# Altium schematic sheet CPU.SCHDOC(CPU)
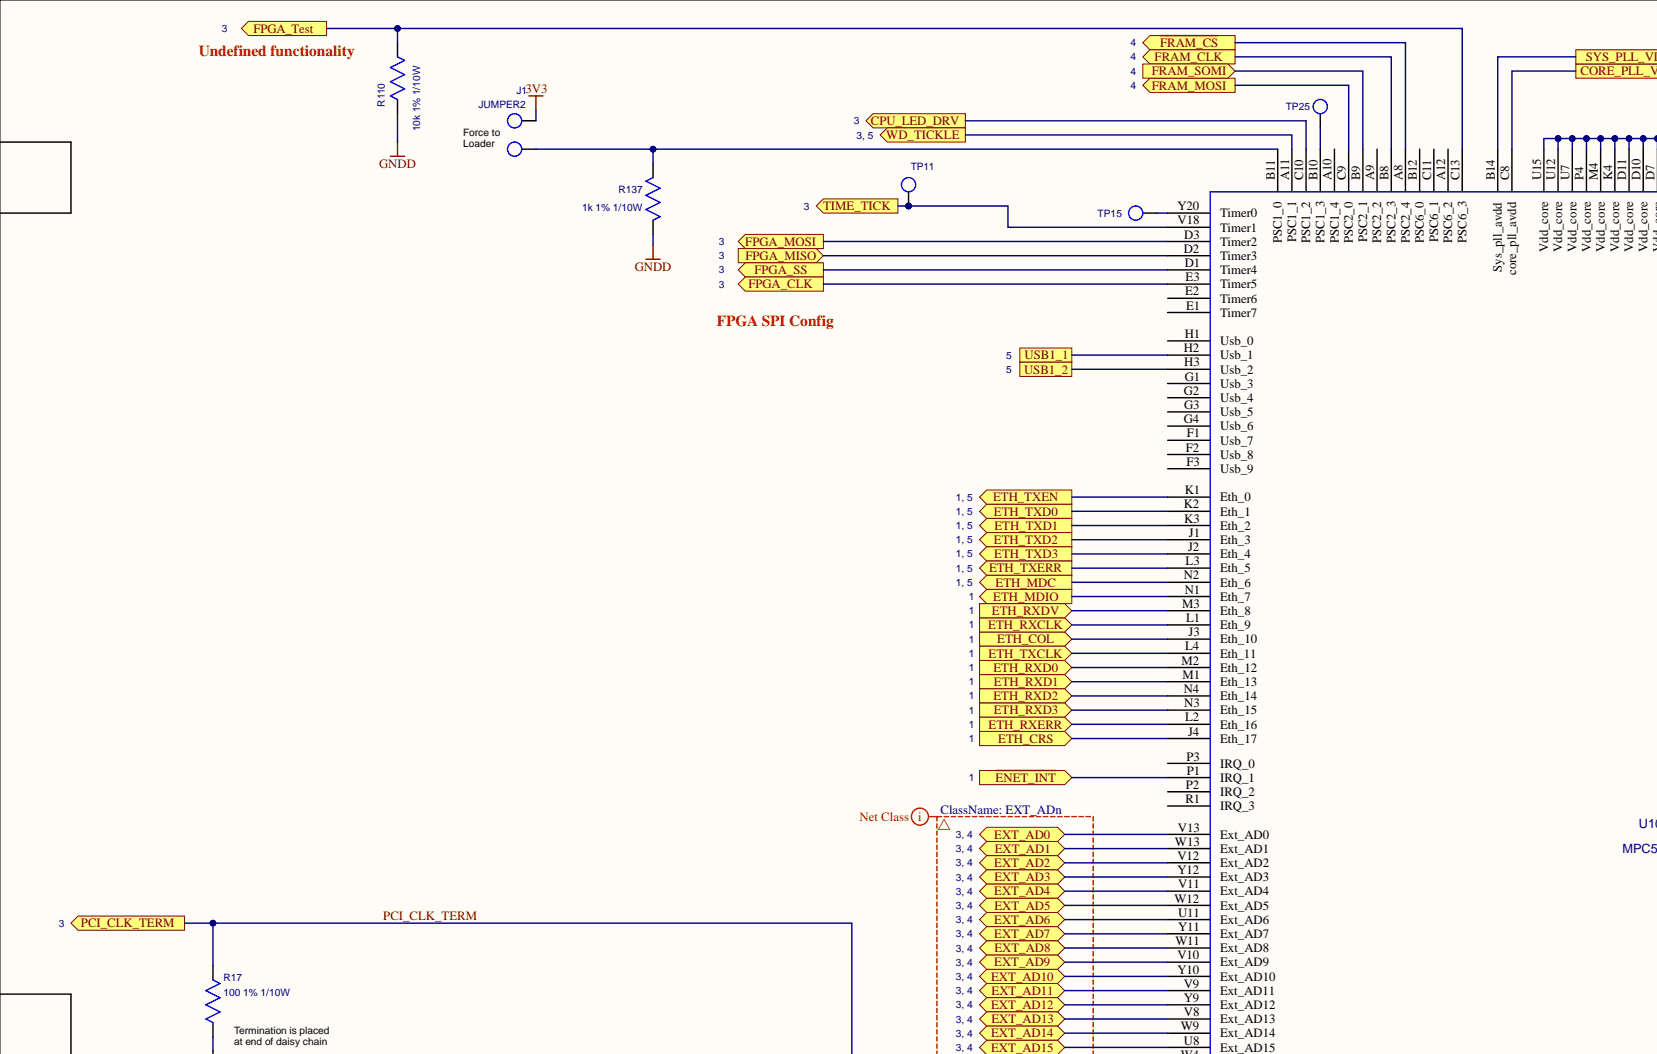
[diagram p0: CPU.SCHDOC, top left]
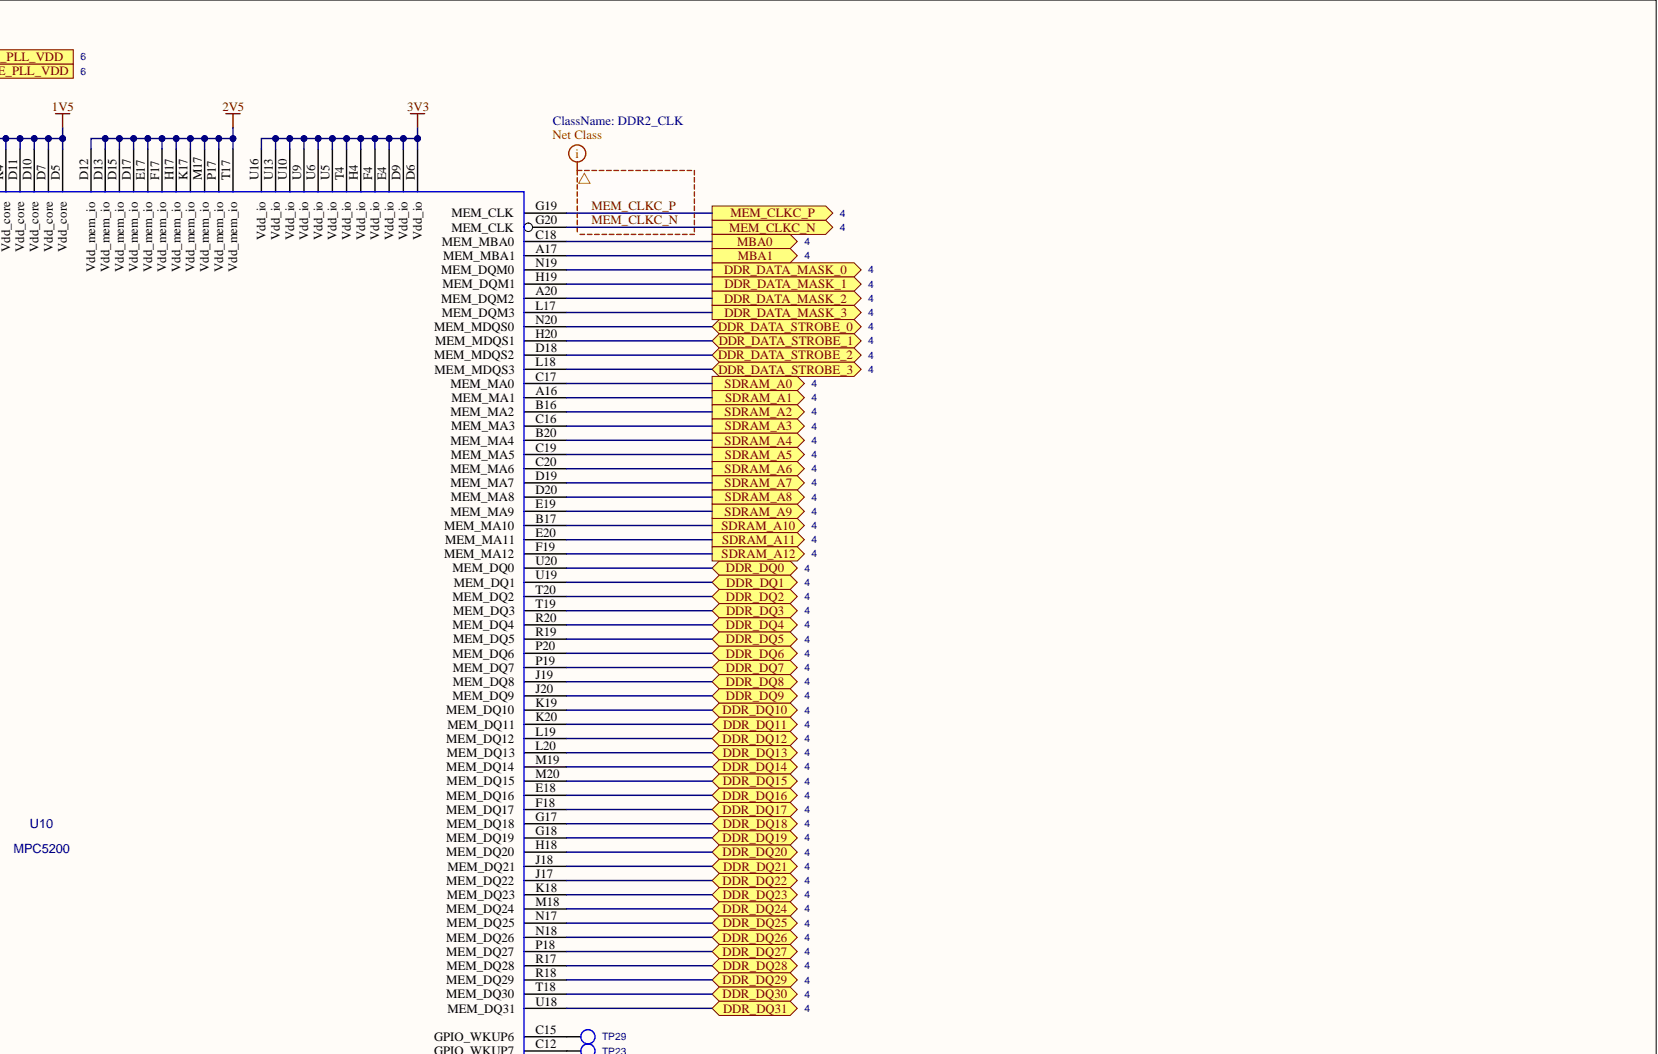
[diagram p1: CPU.SCHDOC, top right]
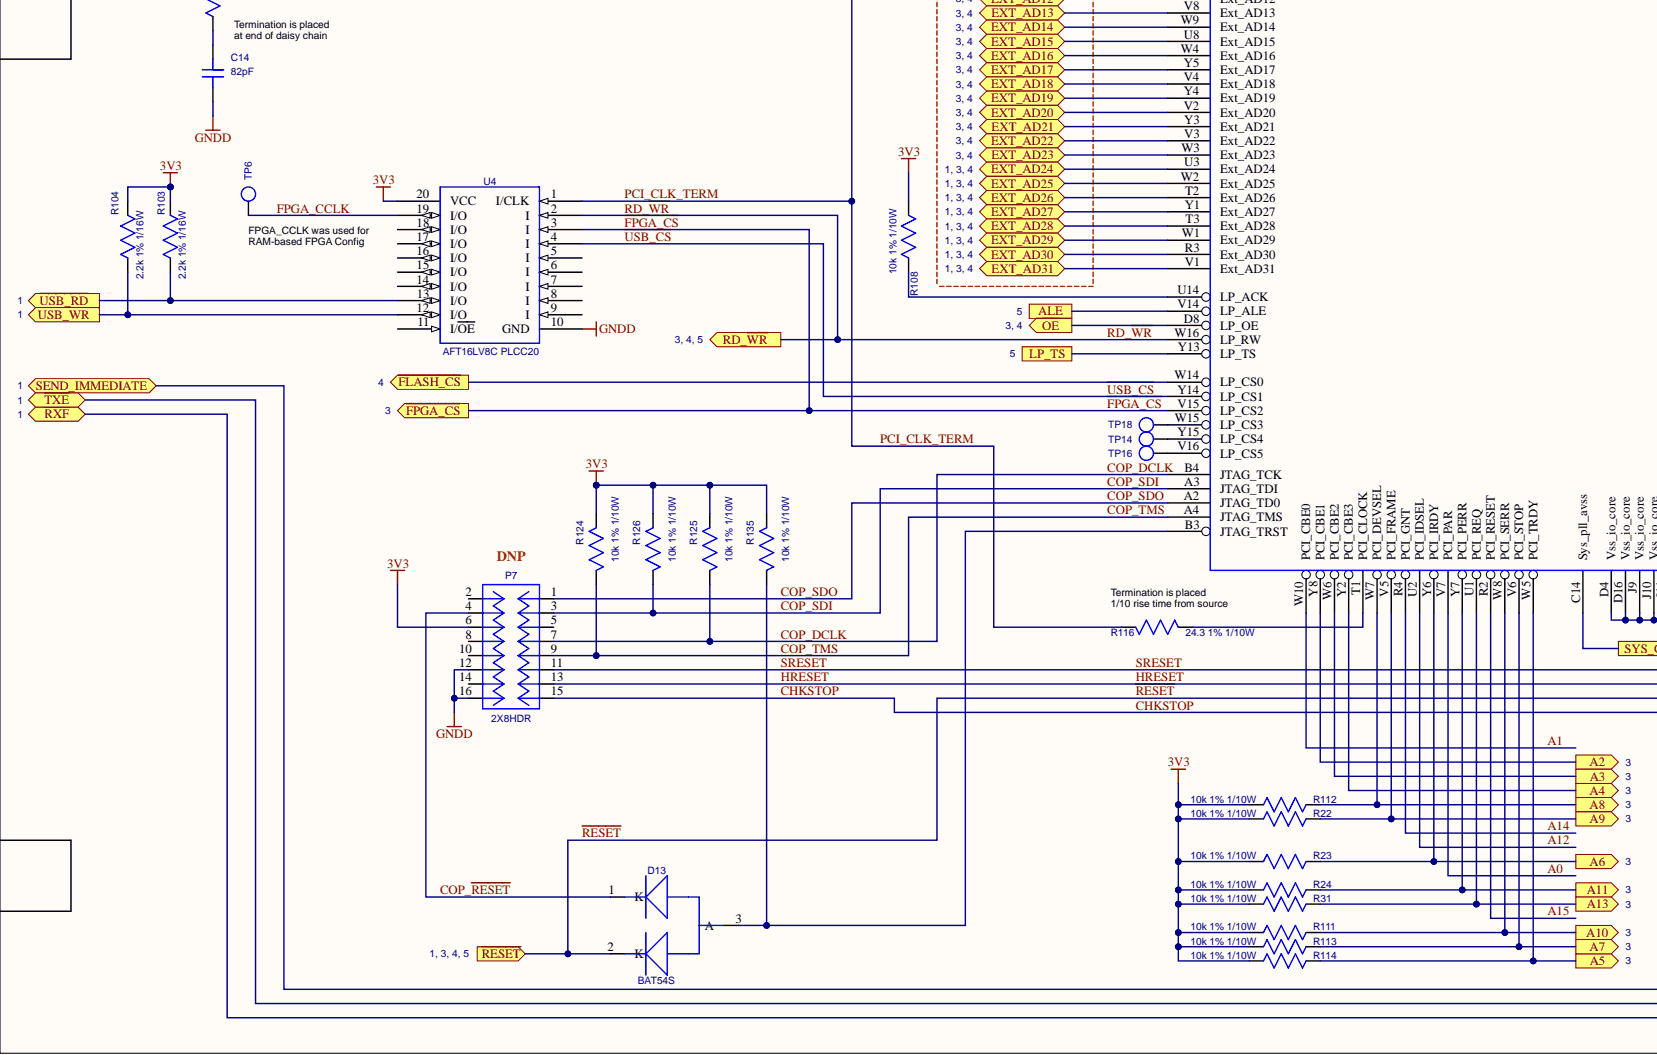
[diagram p2: CPU.SCHDOC, bottom left]
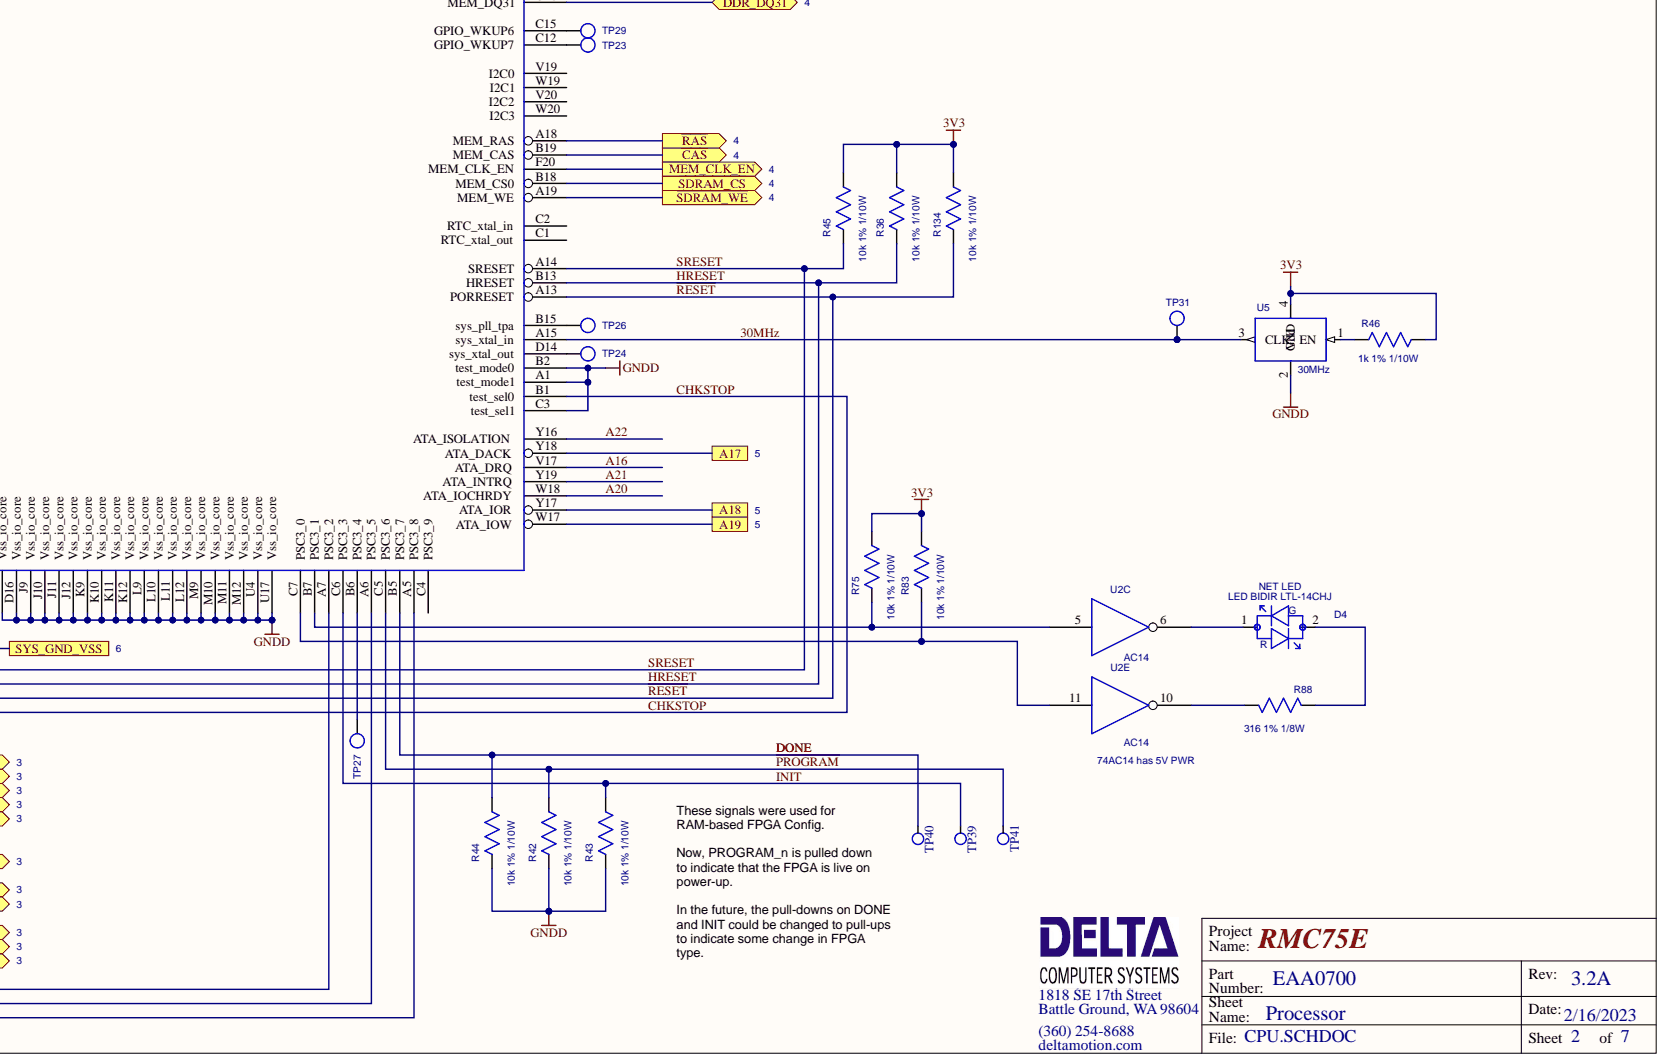
[diagram p3: CPU.SCHDOC, bottom right]

## Parts
C14  82pF  [CC0603]
D4  LED BIDIR LTL-14CHJ  PartNumber: LTL-14CHJ  [LED 0.1 VERT]
D13  BAT54S  [SOT23]
J1  JUMPER2  [JMP2]  (no BOM)
P7  2X8HDR  [2X8HDR 100 MIL]  (no BOM)
R17  100 1% 1/10W  [CC0603]
R22  10k 1% 1/10W  [CC0603]
R23  10k 1% 1/10W  [CC0603]
R24  10k 1% 1/10W  [CC0603]
R31  10k 1% 1/10W  [CC0603]
R36  10k 1% 1/10W  [CC0603]
R42  10k 1% 1/10W  [CC0603]
R43  10k 1% 1/10W  [CC0603]
R44  10k 1% 1/10W  [CC0603]
R45  10k 1% 1/10W  [CC0603]
R46  1k 1% 1/10W  [CC0603]
R75  10k 1% 1/10W  [CC0603]
R83  10k 1% 1/10W  [CC0603]
R88  316 1% 1/8W  [CC0805]
R103  2.2k 1% 1/16W  [CC0603]
R104  2.2k 1% 1/16W  [CC0603]
R108  10k 1% 1/10W  [CC0603]
R110  10k 1% 1/10W  [CC0603]
R111  10k 1% 1/10W  [CC0603]
R112  10k 1% 1/10W  [CC0603]
R113  10k 1% 1/10W  [CC0603]
R114  10k 1% 1/10W  [CC0603]
R116  24.3 1% 1/10W  [CC0603]
R124  10k 1% 1/10W  [CC0603]
R125  10k 1% 1/10W  [CC0603]
R126  10k 1% 1/10W  [CC0603]
R134  10k 1% 1/10W  [CC0603]
R135  10k 1% 1/10W  [CC0603]
R137  1k 1% 1/10W  [CC0603]
TP6  TP_RND_SMT  [TP_SMT_RND]  (value not drawn)  (no BOM)
TP11  TP_RND_SMT  [TP_SMT_RND]  (value not drawn)  (no BOM)
TP14  TP_RND_SMT  [TP_SMT_RND]  (value not drawn)  (no BOM)
TP15  TP_RND_SMT  [TP_SMT_RND]  (value not drawn)  (no BOM)
TP16  TP_RND_SMT  [TP_SMT_RND]  (value not drawn)  (no BOM)
TP18  TP_RND_SMT  [TP_SMT_RND]  (value not drawn)  (no BOM)
TP23  TP_RND_SMT  [TP_SMT_RND]  (value not drawn)  (no BOM)
TP24  TP_RND_SMT  [TP_SMT_RND]  (value not drawn)  (no BOM)
TP25  TP_RND_SMT  [TP_SMT_RND]  (value not drawn)  (no BOM)
TP26  TP_RND_SMT  [TP_SMT_RND]  (value not drawn)  (no BOM)
TP27  TP_RND_SMT  [TP_SMT_RND]  (value not drawn)  (no BOM)
TP29  TP_RND_SMT  [TP_SMT_RND]  (value not drawn)  (no BOM)
TP31  TP_RND_SMT  [TP_SMT_RND]  (value not drawn)  (no BOM)
TP39  Test Point  [TP_SMT_RND_25]  (value not drawn)  (no BOM)
TP40  Test Point  [TP_SMT_RND_25]  (value not drawn)  (no BOM)
TP41  Test Point  [TP_SMT_RND_25]  (value not drawn)  (no BOM)
U2  value AC14  [SO14]  (hidden comment: ACT04-SO14)  (parts C,E)
U4  AFT16LV8C PLCC20  [PLCC20]
U5  30MHz  [OSC-K50]
U10  MPC5200  [BGA27X27-B272_MPC5200(Primary)]

## Ports
A2, A3, A4, A5, A6, A7, A8, A9, A10, A11, A13, A17, A18, A19, ALE, CORE_PLL_VDD, CPU_LED_DRV, DDR_DATA_MASK_0, DDR_DATA_MASK_1, DDR_DATA_MASK_2, DDR_DATA_MASK_3, DDR_DATA_STROBE_0, DDR_DATA_STROBE_1, DDR_DATA_STROBE_2, DDR_DATA_STROBE_3, DDR_DQ0, DDR_DQ1, DDR_DQ2, DDR_DQ3, DDR_DQ4, DDR_DQ5, DDR_DQ6, DDR_DQ7, DDR_DQ8, DDR_DQ9, DDR_DQ10, DDR_DQ11, DDR_DQ12, DDR_DQ13, DDR_DQ14, DDR_DQ15, DDR_DQ16, DDR_DQ17, DDR_DQ18, DDR_DQ19, DDR_DQ20, DDR_DQ21, DDR_DQ22, DDR_DQ23, DDR_DQ24, DDR_DQ25, DDR_DQ26, DDR_DQ27, DDR_DQ28, DDR_DQ29, DDR_DQ30, DDR_DQ31, ETH_COL, ETH_CRS, ETH_MDC, ETH_MDIO, ETH_RXCLK, ETH_RXD0, ETH_RXD1, ETH_RXD2, ETH_RXD3, ETH_RXDV, ETH_RXERR, ETH_TXCLK, ETH_TXD0, ETH_TXD1, ETH_TXD2, ETH_TXD3, ETH_TXEN, ETH_TXERR, EXT_AD0, EXT_AD1, EXT_AD2, EXT_AD3, EXT_AD4, EXT_AD5, EXT_AD6, EXT_AD7, EXT_AD8, EXT_AD9, EXT_AD10, EXT_AD11, EXT_AD12, EXT_AD13, EXT_AD14, EXT_AD15, EXT_AD16, EXT_AD17, EXT_AD18, EXT_AD19, EXT_AD20, EXT_AD21, EXT_AD22, EXT_AD23, EXT_AD24, EXT_AD25, EXT_AD26, EXT_AD27, EXT_AD28, EXT_AD29, EXT_AD30, EXT_AD31, FPGA_CLK, FPGA_MISO, FPGA_MOSI, FPGA_SS, FPGA_Test, FRAM_CLK, FRAM_MOSI, FRAM_SOMI, LP_TS, MBA0, MBA1, MEM_CLK_EN, MEM_CLKC_N, MEM_CLKC_P, PCI_CLK_TERM, RD_~{WR}, SDRAM_A0, SDRAM_A1, SDRAM_A2, SDRAM_A3, SDRAM_A4, SDRAM_A5, SDRAM_A6, SDRAM_A7, SDRAM_A8, SDRAM_A9, SDRAM_A10, SDRAM_A11, SDRAM_A12, SEND_IMMEDIATE, SYS_GND_VSS, SYS_PLL_VDD, TIME_TICK, USB1_1, USB1_2, USB_WR, WD_TICKLE, ~{CAS}, ~{ENET_INT}, ~{FLASH_CS}, ~{FPGA_CS}, ~{FRAM_CS}, ~{OE}, ~{RAS}, ~{RESET}, ~{RXF}, ~{SDRAM_CS}, ~{SDRAM_WE}, ~{TXE}, ~{USB_RD}

## Nets
1V5: U10.D5(Vdd_core) U10.D7(Vdd_core) U10.D10(Vdd_core) U10.D11(Vdd_core) U10.K4(Vdd_core) U10.M4(Vdd_core) U10.P4(Vdd_core) U10.U7(Vdd_core) U10.U12(Vdd_core) U10.U15(Vdd_core)
2V5: U10.D12(Vdd_mem_io) U10.D13(Vdd_mem_io) U10.D15(Vdd_mem_io) U10.D17(Vdd_mem_io) U10.E17(Vdd_mem_io) U10.F17(Vdd_mem_io) U10.H17(Vdd_mem_io) U10.K17(Vdd_mem_io) U10.M17(Vdd_mem_io) U10.P17(Vdd_mem_io) U10.T17(Vdd_mem_io)
3V3: J1.1 P7.6 R22.1 R23.1 R24.1 R31.1 R36.2 R45.2 R46.2 R75.2 R83.2 R103.1 R104.1 R108.1 R111.1 R112.1 R113.1 R114.1 R124.1 R125.1 R126.1 R134.2 R135.1 U4.20(VCC) U5.4(GND) U10.D6(Vdd_io) U10.D9(Vdd_io) U10.E4(Vdd_io) U10.F4(Vdd_io) U10.H4(Vdd_io) U10.T4(Vdd_io) U10.U5(Vdd_io) U10.U6(Vdd_io) U10.U9(Vdd_io) U10.U10(Vdd_io) U10.U13(Vdd_io) U10.U16(Vdd_io)
GNDD: C14.1 P7.12 P7.16 R42.1 R43.1 R44.1 R110.1 R137.2 U4.10(GND) U5.2 U10.A1(test_mode1) U10.B2(test_mode0) U10.C3(test_sel1) U10.D4(Vss_io_core) U10.D16(Vss_io_core) U10.J9(Vss_io_core) U10.J10(Vss_io_core) U10.J11(Vss_io_core) U10.J12(Vss_io_core) U10.K9(Vss_io_core) U10.K10(Vss_io_core) U10.K11(Vss_io_core) U10.K12(Vss_io_core) U10.L9(Vss_io_core) U10.L10(Vss_io_core) U10.L11(Vss_io_core) U10.L12(Vss_io_core) U10.M9(Vss_io_core) U10.M10(Vss_io_core) U10.M11(Vss_io_core) U10.M12(Vss_io_core) U10.U4(Vss_io_core) U10.U17(Vss_io_core)
30MHz: TP31.1 U5.3(CLK) U10.A15(sys_xtal_in)
A0: U10.V7(PCI_PAR)
A1: U10.W10(PCI_CBE0)
A2: U10.Y8(PCI_CBE1) ; port A2
A3: U10.W6(PCI_CBE2) ; port A3
A4: U10.Y2(PCI_CBE3) ; port A4
A5: R114.2 U10.W5(PCI_TRDY) ; port A5
A6: R23.2 U10.Y6(PCI_IRDY) ; port A6
A7: R113.2 U10.V6(PCI_STOP) ; port A7
A8: R112.2 U10.W7(PCI_DEVSEL) ; port A8
A9: R22.2 U10.V5(PCI_FRAME) ; port A9
A10: R111.2 U10.W8(PCI_SERR) ; port A10
A11: R24.2 U10.Y7(PCI_PERR) ; port A11
A12: U10.U2(PCI_IDSEL)
A13: R31.2 U10.U1(PCI_REQ) ; port A13
A14: U10.R4(PCI_GNT)
A15: U10.R2(PCI_RESET)
A16: U10.V17(ATA_DRQ)
A17: U10.Y18(ATA_DACK) ; port A17
A18: U10.Y17(ATA_IOR) ; port A18
A19: U10.W17(ATA_IOW) ; port A19
A20: U10.W18(ATA_IOCHRDY)
A21: U10.Y19(ATA_INTRQ)
A22: U10.Y16(ATA_ISOLATION)
ALE: U10.V14(LP_ALE) ; port ALE
~{CAS}: U10.B19(MEM_CAS) ; port ~{CAS}
CHKSTOP: P7.15 U10.B1(test_sel0)
COP_DCLK: P7.7 R125.2 U10.B4(JTAG_TCK)
COP_~{RESET}: D13.1 P7.4
COP_SDI: P7.3 R126.2 U10.A3(JTAG_TDI)
COP_SDO: P7.1 U10.A2(JTAG_TD0)
COP_TMS: P7.9 R124.2 U10.A4(JTAG_TMS)
CORE_PLL_VDD: U10.C8(core_pll_avdd) ; port CORE_PLL_VDD
CPU_LED_DRV: U10.C10(PSC1_2) ; port CPU_LED_DRV
DDR_DATA_MASK_0: U10.N19(MEM_DQM0) ; port DDR_DATA_MASK_0
DDR_DATA_MASK_1: U10.H19(MEM_DQM1) ; port DDR_DATA_MASK_1
DDR_DATA_MASK_2: U10.A20(MEM_DQM2) ; port DDR_DATA_MASK_2
DDR_DATA_MASK_3: U10.L17(MEM_DQM3) ; port DDR_DATA_MASK_3
DDR_DATA_STROBE_0: U10.N20(MEM_MDQS0) ; port DDR_DATA_STROBE_0
DDR_DATA_STROBE_1: U10.H20(MEM_MDQS1) ; port DDR_DATA_STROBE_1
DDR_DATA_STROBE_2: U10.D18(MEM_MDQS2) ; port DDR_DATA_STROBE_2
DDR_DATA_STROBE_3: U10.L18(MEM_MDQS3) ; port DDR_DATA_STROBE_3
DDR_DQ0: U10.U20(MEM_DQ0) ; port DDR_DQ0
DDR_DQ1: U10.U19(MEM_DQ1) ; port DDR_DQ1
DDR_DQ2: U10.T20(MEM_DQ2) ; port DDR_DQ2
DDR_DQ3: U10.T19(MEM_DQ3) ; port DDR_DQ3
DDR_DQ4: U10.R20(MEM_DQ4) ; port DDR_DQ4
DDR_DQ5: U10.R19(MEM_DQ5) ; port DDR_DQ5
DDR_DQ6: U10.P20(MEM_DQ6) ; port DDR_DQ6
DDR_DQ7: U10.P19(MEM_DQ7) ; port DDR_DQ7
DDR_DQ8: U10.J19(MEM_DQ8) ; port DDR_DQ8
DDR_DQ9: U10.J20(MEM_DQ9) ; port DDR_DQ9
DDR_DQ10: U10.K19(MEM_DQ10) ; port DDR_DQ10
DDR_DQ11: U10.K20(MEM_DQ11) ; port DDR_DQ11
DDR_DQ12: U10.L19(MEM_DQ12) ; port DDR_DQ12
DDR_DQ13: U10.L20(MEM_DQ13) ; port DDR_DQ13
DDR_DQ14: U10.M19(MEM_DQ14) ; port DDR_DQ14
DDR_DQ15: U10.M20(MEM_DQ15) ; port DDR_DQ15
DDR_DQ16: U10.E18(MEM_DQ16) ; port DDR_DQ16
DDR_DQ17: U10.F18(MEM_DQ17) ; port DDR_DQ17
DDR_DQ18: U10.G17(MEM_DQ18) ; port DDR_DQ18
DDR_DQ19: U10.G18(MEM_DQ19) ; port DDR_DQ19
DDR_DQ20: U10.H18(MEM_DQ20) ; port DDR_DQ20
DDR_DQ21: U10.J18(MEM_DQ21) ; port DDR_DQ21
DDR_DQ22: U10.J17(MEM_DQ22) ; port DDR_DQ22
DDR_DQ23: U10.K18(MEM_DQ23) ; port DDR_DQ23
DDR_DQ24: U10.M18(MEM_DQ24) ; port DDR_DQ24
DDR_DQ25: U10.N17(MEM_DQ25) ; port DDR_DQ25
DDR_DQ26: U10.N18(MEM_DQ26) ; port DDR_DQ26
DDR_DQ27: U10.P18(MEM_DQ27) ; port DDR_DQ27
DDR_DQ28: U10.R17(MEM_DQ28) ; port DDR_DQ28
DDR_DQ29: U10.R18(MEM_DQ29) ; port DDR_DQ29
DDR_DQ30: U10.T18(MEM_DQ30) ; port DDR_DQ30
DDR_DQ31: U10.U18(MEM_DQ31) ; port DDR_DQ31
DONE: R44.2 TP40.1 U10.B5(PSC3_7)
~{ENET_INT}: U10.P1(IRQ_1) ; port ~{ENET_INT}
ETH_COL: U10.J3(Eth_10) ; port ETH_COL
ETH_CRS: U10.J4(Eth_17) ; port ETH_CRS
ETH_MDC: U10.N2(Eth_6) ; port ETH_MDC
ETH_MDIO: U10.N1(Eth_7) ; port ETH_MDIO
ETH_RXCLK: U10.L1(Eth_9) ; port ETH_RXCLK
ETH_RXD0: U10.M2(Eth_12) ; port ETH_RXD0
ETH_RXD1: U10.M1(Eth_13) ; port ETH_RXD1
ETH_RXD2: U10.N4(Eth_14) ; port ETH_RXD2
ETH_RXD3: U10.N3(Eth_15) ; port ETH_RXD3
ETH_RXDV: U10.M3(Eth_8) ; port ETH_RXDV
ETH_RXERR: U10.L2(Eth_16) ; port ETH_RXERR
ETH_TXCLK: U10.L4(Eth_11) ; port ETH_TXCLK
ETH_TXD0: U10.K2(Eth_1) ; port ETH_TXD0
ETH_TXD1: U10.K3(Eth_2) ; port ETH_TXD1
ETH_TXD2: U10.J1(Eth_3) ; port ETH_TXD2
ETH_TXD3: U10.J2(Eth_4) ; port ETH_TXD3
ETH_TXEN: U10.K1(Eth_0) ; port ETH_TXEN
ETH_TXERR: U10.L3(Eth_5) ; port ETH_TXERR
EXT_AD0: U10.V13(Ext_AD0) ; port EXT_AD0
EXT_AD1: U10.W13(Ext_AD1) ; port EXT_AD1
EXT_AD2: U10.V12(Ext_AD2) ; port EXT_AD2
EXT_AD3: U10.Y12(Ext_AD3) ; port EXT_AD3
EXT_AD4: U10.V11(Ext_AD4) ; port EXT_AD4
EXT_AD5: U10.W12(Ext_AD5) ; port EXT_AD5
EXT_AD6: U10.U11(Ext_AD6) ; port EXT_AD6
EXT_AD7: U10.Y11(Ext_AD7) ; port EXT_AD7
EXT_AD8: U10.W11(Ext_AD8) ; port EXT_AD8
EXT_AD9: U10.V10(Ext_AD9) ; port EXT_AD9
EXT_AD10: U10.Y10(Ext_AD10) ; port EXT_AD10
EXT_AD11: U10.V9(Ext_AD11) ; port EXT_AD11
EXT_AD12: U10.Y9(Ext_AD12) ; port EXT_AD12
EXT_AD13: U10.V8(Ext_AD13) ; port EXT_AD13
EXT_AD14: U10.W9(Ext_AD14) ; port EXT_AD14
EXT_AD15: U10.U8(Ext_AD15) ; port EXT_AD15
EXT_AD16: U10.W4(Ext_AD16) ; port EXT_AD16
EXT_AD17: U10.Y5(Ext_AD17) ; port EXT_AD17
EXT_AD18: U10.V4(Ext_AD18) ; port EXT_AD18
EXT_AD19: U10.Y4(Ext_AD19) ; port EXT_AD19
EXT_AD20: U10.V2(Ext_AD20) ; port EXT_AD20
EXT_AD21: U10.Y3(Ext_AD21) ; port EXT_AD21
EXT_AD22: U10.V3(Ext_AD22) ; port EXT_AD22
EXT_AD23: U10.W3(Ext_AD23) ; port EXT_AD23
EXT_AD24: U10.U3(Ext_AD24) ; port EXT_AD24
EXT_AD25: U10.W2(Ext_AD25) ; port EXT_AD25
EXT_AD26: U10.T2(Ext_AD26) ; port EXT_AD26
EXT_AD27: U10.Y1(Ext_AD27) ; port EXT_AD27
EXT_AD28: U10.T3(Ext_AD28) ; port EXT_AD28
EXT_AD29: U10.W1(Ext_AD29) ; port EXT_AD29
EXT_AD30: U10.R3(Ext_AD30) ; port EXT_AD30
EXT_AD31: U10.V1(Ext_AD31) ; port EXT_AD31
~{FLASH_CS}: U10.W14(LP_CS0) ; port ~{FLASH_CS}
~{FPGA_CS}: U4.3(I) U10.V15(LP_CS2) ; port ~{FPGA_CS}
~{FRAM_CS}: U10.A8(PSC2_4) ; port ~{FRAM_CS}
FPGA_CCLK: TP6.1 U4.19(I/O)
FPGA_CLK: U10.E3(Timer5) ; port FPGA_CLK
FPGA_MISO: U10.D2(Timer3) ; port FPGA_MISO
FPGA_MOSI: U10.D3(Timer2) ; port FPGA_MOSI
FPGA_SS: U10.D1(Timer4) ; port FPGA_SS
FPGA_Test: R110.2 U10.C13(PSC6_3) ; port FPGA_Test
FRAM_CLK: U10.B8(PSC2_3) ; port FRAM_CLK
FRAM_MOSI: U10.C9(PSC2_0) ; port FRAM_MOSI
FRAM_SOMI: U10.B9(PSC2_1) ; port FRAM_SOMI
HRESET: P7.13 R36.1 U10.B13(HRESET)
INIT: R43.2 TP39.1 U10.C6(PSC3_3)
LP_TS: U10.Y13(LP_TS) ; port LP_TS
MBA0: U10.C18(MEM_MBA0) ; port MBA0
MBA1: U10.A17(MEM_MBA1) ; port MBA1
MEM_CLK_EN: U10.F20(MEM_CLK_EN) ; port MEM_CLK_EN
MEM_CLKC_N: U10.G20(MEM_CLK) ; port MEM_CLKC_N
MEM_CLKC_P: U10.G19(MEM_CLK) ; port MEM_CLKC_P
~{OE}: U10.D8(LP_OE) ; port ~{OE}
~{PROGRAM}: R42.2 TP41.1 U10.C5(PSC3_6)
PCI_CLK_TERM: R17.2 R116.1 U4.1(I/CLK) ; port PCI_CLK_TERM
~{RAS}: U10.A18(MEM_RAS) ; port ~{RAS}
~{RESET}: D13.2 R134.1 U10.A13(PORRESET) ; port ~{RESET}
~{RXF}: U10.A5(PSC3_8) ; port ~{RXF}
RD_~{WR}: U4.2(I) U10.W16(LP_RW) ; port RD_~{WR}
~{SDRAM_CS}: U10.B18(MEM_CS0) ; port ~{SDRAM_CS}
~{SDRAM_WE}: U10.A19(MEM_WE) ; port ~{SDRAM_WE}
SDRAM_A0: U10.C17(MEM_MA0) ; port SDRAM_A0
SDRAM_A1: U10.A16(MEM_MA1) ; port SDRAM_A1
SDRAM_A2: U10.B16(MEM_MA2) ; port SDRAM_A2
SDRAM_A3: U10.C16(MEM_MA3) ; port SDRAM_A3
SDRAM_A4: U10.B20(MEM_MA4) ; port SDRAM_A4
SDRAM_A5: U10.C19(MEM_MA5) ; port SDRAM_A5
SDRAM_A6: U10.C20(MEM_MA6) ; port SDRAM_A6
SDRAM_A7: U10.D19(MEM_MA7) ; port SDRAM_A7
SDRAM_A8: U10.D20(MEM_MA8) ; port SDRAM_A8
SDRAM_A9: U10.E19(MEM_MA9) ; port SDRAM_A9
SDRAM_A10: U10.B17(MEM_MA10) ; port SDRAM_A10
SDRAM_A11: U10.E20(MEM_MA11) ; port SDRAM_A11
SDRAM_A12: U10.F19(MEM_MA12) ; port SDRAM_A12
SEND_IMMEDIATE: U10.A7(PSC3_2) ; port SEND_IMMEDIATE
SRESET: P7.11 R45.1 U10.A14(SRESET)
SYS_GND_VSS: U10.C14(Sys_pll_avss) ; port SYS_GND_VSS
SYS_PLL_VDD: U10.B14(Sys_pll_avdd) ; port SYS_PLL_VDD
~{TXE}: U10.A6(PSC3_5) ; port ~{TXE}
TIME_TICK: TP11.1 U10.V18(Timer1) ; port TIME_TICK
~{USB_CS}: U4.4(I) U10.Y14(LP_CS1)
~{USB_RD}: R103.2 U4.13(I/O) ; port ~{USB_RD}
USB1_1: U10.H2(Usb_1) ; port USB1_1
USB1_2: U10.H3(Usb_2) ; port USB1_2
USB_WR: R104.2 U4.12(I/O) ; port USB_WR
WD_TICKLE: U10.A11(PSC1_1) ; port WD_TICKLE
NetC14_2: C14.2 R17.1
NetD4_1: D4.1 U2.6
NetD4_2: D4.2 R88.1
NetD13_3: D13.3 R135.2 U10.B3(JTAG_TRST)
NetJ1_2: J1.2 R137.1 U10.B11(PSC1_0)
NetR46_1: R46.1 U5.1(EN)
NetR75_1: R75.1 U2.5 U10.B7(PSC3_1)
NetR83_1: R83.1 U2.11 U10.C7(PSC3_0)
NetR88_2: R88.2 U2.10
NetR108_2: R108.2 U10.U14(LP_ACK)
NetR116_2: R116.2 U10.T1(PCI_CLOCK)
NetTP14_1: TP14.1 U10.Y15(LP_CS4)
NetTP15_1: TP15.1 U10.Y20(Timer0)
NetTP16_1: TP16.1 U10.V16(LP_CS5)
NetTP18_1: TP18.1 U10.W15(LP_CS3)
NetTP23_1: TP23.1 U10.C12(GPIO_WKUP7)
NetTP24_1: TP24.1 U10.D14(sys_xtal_out)
NetTP25_1: TP25.1 U10.B10(PSC1_3)
NetTP26_1: TP26.1 U10.B15(sys_pll_tpa)
NetTP27_1: TP27.1 U10.B6(PSC3_4)
NetTP29_1: TP29.1 U10.C15(GPIO_WKUP6)
5V:

Not connected: P7 2 5 8 10 14; U4 5(I) 6(I) 7(I) 8(I) 9(I) 11(I/OE) 14(I/O) 15(I/O) 16(I/O) 17(I/O) 18(I/O); U10 A9(PSC2_2) A10(PSC1_4) A12(PSC6_2) B12(PSC6_0) C1(RTC_xtal_out) C2(RTC_xtal_in) C4(PSC3_9) C11(PSC6_1) E1(Timer7) E2(Timer6) F1(Usb_7) F2(Usb_8) F3(Usb_9) G1(Usb_3) G2(Usb_4) G3(Usb_5) G4(Usb_6) H1(Usb_0) P2(IRQ_2) P3(IRQ_0) R1(IRQ_3) V19(I2C0) V20(I2C2) W19(I2C1) W20(I2C3)

## Library data of the parts
C14 = CAPNP_SMT0603: Cap Cer 82pF 50V 5% 0603 C0G; Manufacturer: Venkel; PartNumber: C0603C0G500-820JNE; Component Kind: Standard; Value: 82pF
D4 = LED BIDIR LTL-14CHJ: LED Green/Red Diff 3mm Round T1; Manufacturer: Lite On; Component Kind: Standard
D13 = BAT54A: Diode Array Schottky 30V 200mA SOT23-3; Manufacturer: On Semi; Component Kind: Standard; PartNumber: BAT54S
R17 = RES_GEN_SMT0603: Res 100 1% 1/10W 100ppm 0603; Manufacturer: Venkel; PartNumber: CR0603-10W-1000FT; Component Kind: Standard; Value: 100 1% 1/10W
R22-R24 R31 R36 R42-R45 R75 R83 R108 R110-R114 R124-R126 R134 R135 = RES_GEN_SMT0603: Res 10k 1% 1/10W 100ppm 0603; Manufacturer: Venkel; PartNumber: CR0603-10W-1002FT; Component Kind: Standard; Value: 10k 1% 1/10W
R46 R137 = RES_GEN_SMT0603: Res 1k 1% 1/10W 100ppm 0603; Manufacturer: Venkel; PartNumber: CR0603-10W-1001FT; Component Kind: Standard; Value: 1k 1% 1/10W
R88 = RES_GEN_SMT0805: Res 316 1% 1/8W 100ppm 0805; Manufacturer: Venkel; PartNumber: CR0805-8W-3160FT; Component Kind: Standard; Value: 316 1% 1/8W
R103 R104 = RES_GEN_SMT0603: Res 2.2k 1% 1/10W 100ppm 0603; Manufacturer: Venkel; PartNumber: CR0603-10W-2201FT; Component Kind: Standard; Value: 2.2k 1% 1/16W
R116 = RES_GEN_SMT0603: Res 24.3 1% 1/10W 100ppm 0603; Manufacturer: Venkel; PartNumber: CR0603-10W-24R3FT; Component Kind: Standard; Value: 24.3 1% 1/10W
TP39-TP41 = Test Point: Generic Test Point; ComponentType: Connector; DateUpdated: 10/19/2010; Library Name: Connector.IntLib
U2 = ACT04-SO14: Hex Inverter Schmitt Trigger 6-Ch 6-Inp 14SOIC; Manufacturer: Fairchild; PartNumber: 74ACT14SCX; Component Kind: Standard
U4 = ATF16V8BQL PLCC20: PLD Programmed/R70-Enet 10nS 20PLCC; Manufacturer: Atmel; PartNumber: ATF16LV8C-10JU/R70-Enet; Component Kind: Standard; Value: AFT16LV8C PLCC20
U5 = OSC-K50: Osc XO 30 MHz 3.3V HCMOS TTL 7x5mm 4SMD; Manufacturer: CTS; PartNumber: CB3LV-3I-30M0000; Component Kind: Standard; Value: 30MHz
U10 = MPC5200: MPU 32bit 400MHz 272BGA; Manufacturer: NXP; PartNumber: MPC5200CVR400B *RoHS*; Component Kind: Standard
J1 = JUMPER2: Description: JUMPER2
P7 = 2X8HDR: Description: 2X8HDR
TP6 TP11 TP14-TP16 TP18 TP23-TP27 TP29 TP31 = TP_RND_SMT: Description: TP_RND_SMT
